# Altium schematic sheet Sheet4.SchDoc(Sheet4)
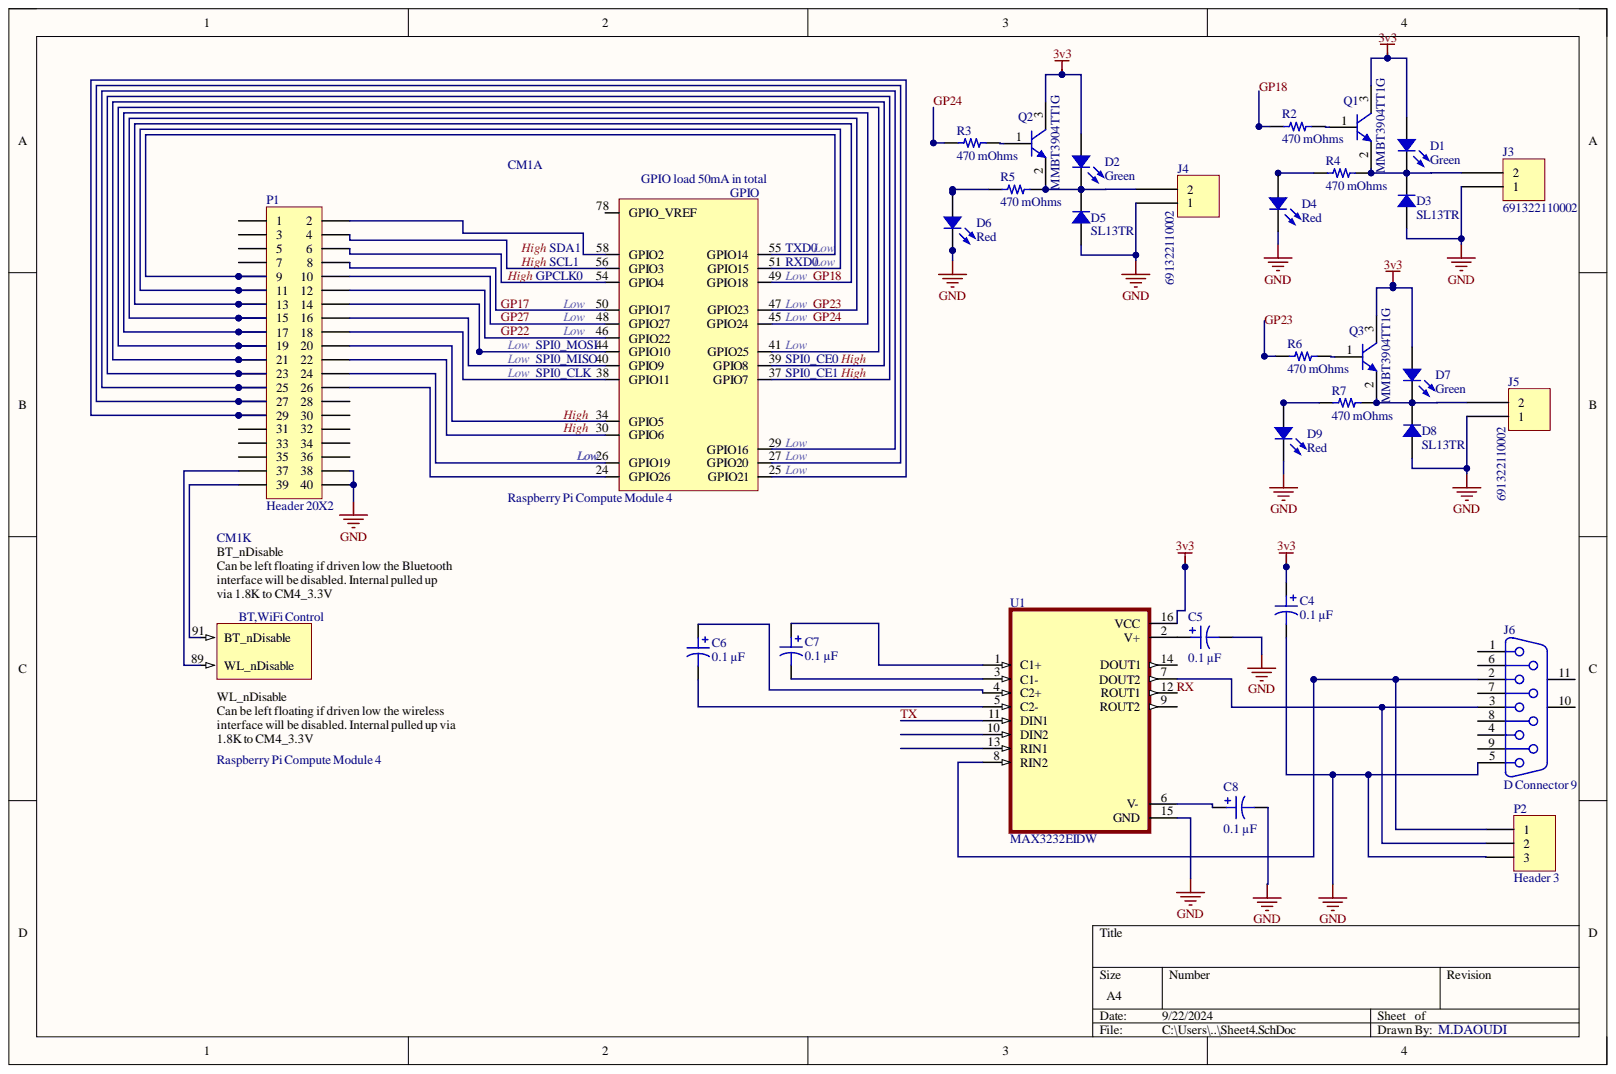
[diagram p0: Sheet4.SchDoc]

## Parts
C4  0.1 µF  [CAP POL 1206_3216]
C5  0.1 µF  [CAP POL 1206_3216]
C6  0.1 µF  [CAP POL 1206_3216]
C7  0.1 µF  [CAP POL 1206_3216]
C8  0.1 µF  [CAP POL 1206_3216]
CM1  Raspberry Pi Compute Module 4  [CM4-F40C-100DS-0.4v_1.5mm_0mm]  (no BOM)  (parts A,K)
D1  Green  [LED 1206_3216 GREEN]
D2  Green  [LED 1206_3216 GREEN]
D3  SL13TR  [DO214AC SMA]
D4  Red  [LED 1206_3216 RED]
D5  SL13TR  [DO214AC SMA]
D6  Red  [LED 1206_3216 RED]
D7  Green  [LED 1206_3216 GREEN]
D8  SL13TR  [DO214AC SMA]
D9  Red  [LED 1206_3216 RED]
J3  691322110002  Color: Green  [WURTH 691322110002]
J4  691322110002  Color: Green  [WURTH 691322110002]
J5  691322110002  Color: Green  [WURTH 691322110002]
J6  D Connector 9  [DSUB1.385-2H9]
P1  Header 20X2  [HDR2X20]
P2  Header 3  [HDR1X3]
Q1  MMBT3904TT1G  [ON SEMICONDUCTOR SC-75]
Q2  MMBT3904TT1G  [ON SEMICONDUCTOR SC-75]
Q3  MMBT3904TT1G  [ON SEMICONDUCTOR SC-75]
R2  470 mOhms  [RES 0402_1005]
R3  470 mOhms  [RES 0402_1005]
R4  470 mOhms  [RES 0402_1005]
R5  470 mOhms  [RES 0402_1005]
R6  470 mOhms  [RES 0402_1005]
R7  470 mOhms  [RES 0402_1005]
U1  MAX3232EIDW  [SOIC127P1030X265-16N]

## Nets
3v3: C4.1 D1.1 D2.1 D7.1 Q1.3 Q2.3 Q3.3 U1.16(VCC)
GND: C4.2 C5.2 C8.2 D3.1 D4.2 D5.1 D6.2 D8.1 D9.2 J3.1 J4.1 J5.1 J6.5 P1.38 P1.40 P2.3 U1.15(GND)
GP17: CM1.50(GPIO17) P1.8
GP18: CM1.49(GPIO18) P1.13 R2.1
GP22: CM1.46(GPIO22) P1.12
GP23: CM1.47(GPIO23) P1.15 R6.1
GP24: CM1.45(GPIO24) P1.17 R3.1
GP27: CM1.48(GPIO27) P1.10
RX: U1.12(ROUT1)
TX: U1.11(DIN1)
NetC5_1: C5.1 U1.2(V+)
NetC6_1: C6.1 U1.4(C2+)
NetC6_2: C6.2 U1.5(C2-)
NetC7_1: C7.1 U1.1(C1+)
NetC7_2: C7.2 U1.3(C1-)
NetC8_1: C8.1 U1.6(V-)
NetCM1_24: CM1.24(GPIO26) P1.26
NetCM1_25: CM1.25(Phunsin) P1.29
NetCM1_26: CM1.26(GPIO19) P1.24
NetCM1_27: CM1.27(GPIO20) P1.27
NetCM1_29: CM1.29(GPIO16) P1.25
NetCM1_30: CM1.30(GPIO6) P1.22
NetCM1_34: CM1.34(GPIO5) P1.20
NetCM1_37: CM1.37(GPIO7) P1.23
NetCM1_38: CM1.38(GPIO11) P1.18
NetCM1_39: CM1.39(GPIO8) P1.21
NetCM1_40: CM1.40(GPIO9) P1.16
NetCM1_41: CM1.41(GPIO25) P1.19
NetCM1_44: CM1.44(GPIO10) P1.14
NetCM1_51: CM1.51(GPIO15) P1.11
NetCM1_54: CM1.54(GPIO4) P1.6
NetCM1_55: CM1.55(GPIO14) P1.9
NetCM1_56: CM1.56(GPIO3) P1.4
NetCM1_58: CM1.58(GPIO2) P1.2
NetCM1_89: CM1.89(WL_nDisable) P1.37
NetCM1_91: CM1.91(BT_nDisable) P1.39
NetD1_2: D1.2 D3.2 J3.2 Q1.2 R4.2
NetD2_2: D2.2 D5.2 J4.2 Q2.2 R5.2
NetD4_1: D4.1 R4.1
NetD6_1: D6.1 R5.1
NetD7_2: D7.2 D8.2 J5.2 Q3.2 R7.2
NetD9_1: D9.1 R7.1
NetJ6_2: J6.2 P2.1 U1.8(RIN2)
NetJ6_3: J6.3 P2.2 U1.7(DOUT2)
NetQ1_1: Q1.1 R2.2
NetQ2_1: Q2.1 R3.2
NetQ3_1: Q3.1 R6.2

Not connected: CM1 78(GPIO_VREF); J6 1 4 6 7 8 9 10 11; P1 1 3 5 7 28 30 31 32 33 34 35 36; U1 9(ROUT2) 10(DIN2) 13(RIN1) 14(DOUT1)

## Library data of the parts
C4-C8 = TAJS104K020RNJ: CAP TANT 0.1UF 10% 20V 1206; manufacturer: KYOCERA AVX; Manufacturer Size Code: S; Database Table Name: Capacitors - Tantalum; ESR (Equivalent Series Resistance): 25Ohm; Type: Molded; Capacitance: 0.1 µF; Component Kind: Standard; componentlink1description: Datasheet; componentlink1url: https://datasheets.kyocera-avx.com/TAJ.pdf; componentlink2description: DigiKey Link; componentlink2url: https://www.digikey.com/en/products/detail/kyocera-avx/TAJS104K020RNJ/1470422; Device Package: 1206 (3216 Metric); Features: General Purpose; Height - Seated (Max): 0.047" (1.20mm); lastupdated: 6/3/2024 7:29:26 PM; Library Name: Celestial Altium Library - altium_library.DbLib; Lifecycle Status: Active; Minimum Order: 1; Mounting Type: Surface Mount; Operating Temperature: -55°C ~ 125°C; Package / Case: 1206 (3216 Metric); packaging: TapeAndReel; Part Number: TAJS104K020RNJ; partid: 5878; price: 0.27; series: TAJ; Size / Dimension: 0.126" L x 0.063" W (3.20mm x 1.60mm); Supplier 1: DigiKey; Supplier Part Number 1: 478-TAJS104K020RNJCT-ND; Tolerance: ±10%; Value: 0.1 µF; Voltage - Rated: 20 V
CM1 = CM4: Raspberry Pi Compute Module 4 by Amornthep Phunsin; Library Name: CM4.SchLib
D1 D2 D7 = 597-6322-107F: LED GREEN 1206 SMD; Manufacturer: Dialight; Current - Test: 20mA; Database Table Name: LED - Indication; Lens Size: 1.20mm Dia; Lens Style: Round with Domed Top; Millicandela Rating: 1278.5mcd; Viewing Angle: 30°; Voltage - Forward (Vf) (Typ): 3.2V; Wavelength - Dominant: 525nm; Color: Green; Component Kind: Standard; ComponentLink1Description: Datasheet; ComponentLink1URL: https://s3-us-west-2.amazonaws.com/catsy.557/C18571.pdf; ComponentLink2Description: DigiKey Link; ComponentLink2URL: https://www.digikey.com/en/products/detail/dialight/597-6322-107F/16995758; Device Package: 1206 (3216 Metric); Height (Max): 1.73mm; LastUpdated: 6/3/2024 7:59:09 PM; Library Name: Celestial Altium Library - altium_library.DbLib; Lifecycle Status: Active; Minimum Order: 1; Mounting Type: Surface Mount; Package / Case: 1206 (3216 Metric); Packaging: TapeAndReel; Part Number: 597-6322-107F; Part Status: Active; PartId: 1292; Price: 0.17; Series: -; Size / Dimension: 3.20mm L x 1.60mm W; Supplier 1: DigiKey; Supplier Device Package: 1206; Supplier Part Number 1: 350-597-6322-107FCT-ND; Value: Green
D3 D5 D8 = SL13TR: DIODE SCHOTTKY 30V 1A SMA; Manufacturer: SMC Diode Solutions; Current - Reverse Leakage @ Vr: 500 µA @ 30 V; Database Table Name: Diodes - Rectifiers - Single; Speed: Fast Recovery =< 500ns, > 200mA (Io); Voltage - Forward (Vf) (Max) @ If: 380 mV @ 1 A; Component Kind: Standard; ComponentLink1Description: Datasheet; ComponentLink1URL: http://www.smc-diodes.com/propdf/SL12-SL14%20N0975%20REV.A.pdf; ComponentLink2Description: DigiKey Link; ComponentLink2URL: https://www.digikey.com/en/products/detail/smc-diode-solutions/SL13TR/5993518; Current - Average Rectified (Io): 1A; Diode Type: Schottky; LastUpdated: 6/3/2024 7:54:29 PM; Library Name: Celestial Altium Library - altium_library.DbLib; Lifecycle Status: Active; Minimum Order: 1; Mounting Type: Surface Mount; Operating Temperature - Junction: -65°C ~ 125°C; Package / Case: DO-214AC, SMA; Packaging: TapeAndReel; Part Number: SL13TR; Part Status: Active; PartId: 2188; Price: 0.08; Series: -; Supplier 1: DigiKey; Supplier Device Package: SMA (DO-214AC); Supplier Part Number 1: 1655-2289-1-ND; Voltage - DC Reverse (Vr) (Max): 30 V
D4 D6 D9 = 597-6022-107F: LED RED 1206 SMD; Manufacturer: Dialight; Current - Test: 20mA; Database Table Name: LED - Indication; Lens Size: 1.20mm Dia; Lens Style: Round with Domed Top; Millicandela Rating: 1000mcd; Viewing Angle: 30°; Voltage - Forward (Vf) (Typ): 2.1V; Wavelength - Dominant: 625nm; Color: Red; Component Kind: Standard; ComponentLink1Description: Datasheet; ComponentLink1URL: https://s3-us-west-2.amazonaws.com/catsy.557/C18572.pdf; ComponentLink2Description: DigiKey Link; ComponentLink2URL: https://www.digikey.com/en/products/detail/dialight/597-6022-107F/16997784; Device Package: 1206 (3216 Metric); Height (Max): 1.73mm; LastUpdated: 6/3/2024 8:00:39 PM; Library Name: Celestial Altium Library - altium_library.DbLib; Lifecycle Status: Active; Minimum Order: 1; Mounting Type: Surface Mount; Package / Case: 1206 (3216 Metric); Packaging: TapeAndReel; Part Number: 597-6022-107F; Part Status: Active; PartId: 1303; Price: 0.15; Series: -; Size / Dimension: 3.20mm L x 1.60mm W; Supplier 1: DigiKey; Supplier Device Package: 1206; Supplier Part Number 1: 350-597-6022-107FCT-ND; Value: Red
J3-J5 = 691322110002: TERM BLOCK HDR 2POS 90DEG 3.5MM; Manufacturer: Würth Elektronik; Current - UL: 10 A; Database Table Name: Connectors - Terminal Blocks - Headers Plugs and Sockets; Header Orientation: 90°, Right Angle; Number of Levels: 1; Number of Positions: 2; Pitch: 0.138" (3.50mm); Voltage - UL: 300 V; Component Kind: Standard; ComponentLink1Description: Datasheet; ComponentLink1URL: https://katalog.we-online.de/em/datasheet/6913221100xx.pdf; ComponentLink2Description: DigiKey Link; ComponentLink2URL: https://www.digikey.com/en/products/detail/würth-elektronik/691322110002/2508538; Contact Mating Finish: Tin; LastUpdated: 6/21/2022 2:48:48 PM; Library Name: Celestial Altium Library - altium_library.DbLib; Lifecycle Status: Active; Minimum Order: 1; Mounting Type: Through Hole; Operating Temperature: -40°C ~ 105°C; Packaging: Bulk; Part Number: 691322110002; Part Status: Active; Positions Per Level: 2; Price: 0.26; Series: WR-TBL Series 3221; Supplier 1: DigiKey; Supplier Part Number 1: 732-2769-ND; Termination Style: Solder; Type: Header, Male Pins, Shrouded (4 Side)
J6 = D Connector 9: Receptacle Assembly, 9 Position, Right Angle; PackageDocument: O; Positions: 9; Component Kind: Standard; DatasheetDocument: 1-Sep-1999; Gender: Receptacle; LatestRevisionDate: 17-Jul-2002; LatestRevisionNote: Re-released for DXP Platform.; Library Name: Miscellaneous Connectors.IntLib; Orientation: Right Angle; PackageReference: 788750; Published: 15-Jun-2001; Signal Integrity: Connector; Publisher: Altium Limited
P1 = Header 20X2: Header, 20-Pin, Dual row; Component Kind: Standard; LatestRevisionDate: 17-Jul-2002; LatestRevisionNote: Re-released for DXP Platform.; Library Name: Miscellaneous Connectors.IntLib; Signal Integrity: Connector; Publisher: Altium Limited
P2 = Header 3: Header, 3-Pin; Component Kind: Standard; LatestRevisionDate: 17-Jul-2002; LatestRevisionNote: Re-released for DXP Platform.; Library Name: Miscellaneous Connectors.IntLib; Signal Integrity: Connector; Publisher: Altium Limited
Q1-Q3 = MMBT3904TT1G: TRANS NPN 40V 0.2A SOT416; Manufacturer: ON Semiconductor; Current - Collector (Ic) (Max): 200mA; Database Table Name: Transistors - Bipolar BJT - Single; DC Current Gain (hFE) (Min) @ Ic, Vce: 100 @ 10mA, 1V; Frequency - Transition: 300MHz; Power - Max: 300mW; Vce Saturation (Max) @ Ib, Ic: 300mV @ 5mA, 50mA; Component Kind: Standard; ComponentLink1Description: Datasheet; ComponentLink1URL: https://www.onsemi.com/pub/Collateral/MMBT3904TT1-D.PDF; ComponentLink2Description: DigiKey Link; ComponentLink2URL: http://digikey.com/product-detail/en/on-semiconductor/MMBT3904TT1G/MMBT3904TT1GOSCT-ND/1139815; LastUpdated: 6/3/2024 8:24:07 PM; Library Name: Celestial Altium Library - altium_library.DbLib; Lifecycle Status: Active; Minimum Order: 1; Mounting Type: Surface Mount; Operating Temperature: -55°C ~ 150°C (TJ); Package / Case: SC-75, SOT-416; Packaging: TapeAndReel; Part Number: MMBT3904TT1G; Part Status: Active; PartId: 34; Price: 0.02; Series: -; Supplier 1: DigiKey; Supplier Device Package: SC-75, SOT-416; Supplier Part Number 1: MMBT3904TT1GOSCT-ND; Transistor Type: NPN; Voltage - Collector Emitter Breakdown (Max): 40V
R2-R7 = 73L1R47J: RES 0.47 OHM 5% 1/10W 0402; Manufacturer: CTS Resistor Products; Database Table Name: Resistors - Surface Mount - 0402; Power (Watts): 0.1W, 1/10W; Temperature Coefficient: ±300ppm/°C; Component Kind: Standard; ComponentLink1Description: Datasheet; ComponentLink1URL: https://www.ctscorp.com/wp-content/uploads/2015/11/008-0337-0.pdf; ComponentLink2Description: DigiKey Link; ComponentLink2URL: https://www.digikey.com/en/products/detail/cts-resistor-products/73L1R47J/1543472; Composition: Thick Film; Device Package: 0402 (1005 Metric); Features: Current Sense; Height - Seated (Max): 0.016" (0.40mm); LastUpdated: 2/9/2024 8:29:22 PM; Library Name: Celestial Altium Library - altium_library.DbLib; Lifecycle Status: Active; Minimum Order: 1; Number of Terminations: 2; Operating Temperature: -55°C ~ 125°C; Package / Case: 0402 (1005 Metric); Packaging: TapeAndReel; Part Number: 73L1R47J; Part Status: Active; PartId: 20067; Price: 0.01; Resistance: 470 mOhms; Series: 73L1; Size / Dimension: 0.039" L x 0.020" W (1.00mm x 0.50mm); Supplier 1: DigiKey; Supplier Device Package: 0402; Supplier Part Number 1: 73L1R47JCT-ND; Tolerance: ±5%; Value: 470 mOhms
U1 = MAX3232EIDW: 3- to 5.5-V dual channel 250kbps RS-232 line driver/receiver with +/-15-kV IEC-ESD protection; MF: Texas Instruments; Availability: In Stock; Check_prices: https://www.snapeda.com/parts/MAX3232EIDW/Texas+Instruments/view-part/?ref=eda; Component Kind: Standard; Library Name: MAX3232EIDW.IntLib; MP: MAX3232EIDW; Package: SOIC-16 Texas Instruments; Price: None; Purchase-URL: https://pricing.snapeda.com/search/part/MAX3232EIDW/?ref=eda; SnapEDA_Link: https://www.snapeda.com/parts/MAX3232EIDW/Texas+Instruments/view-part/?ref=snap
